# Altium schematic sheet power.SchDoc(power)
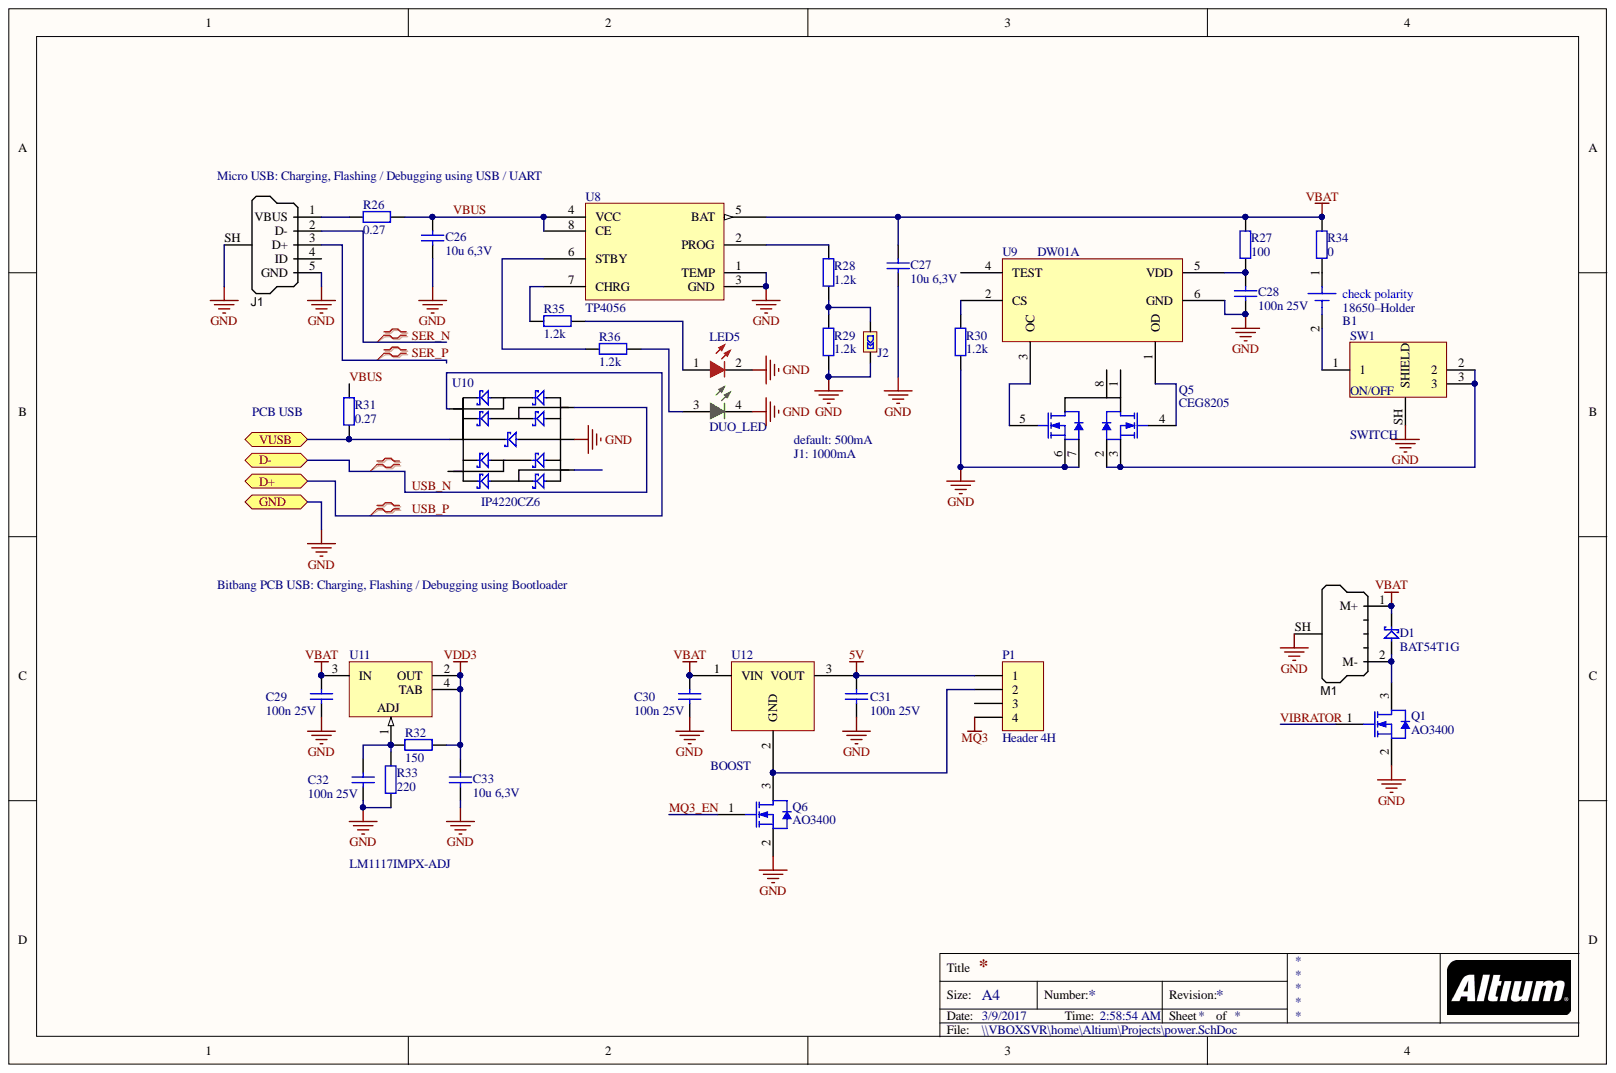
[diagram p0: power.SchDoc]

## Parts
B1  18650–Holder  [18650–Holder]
C26  10u 6,3V  [C-0603-H]
C27  10u 6,3V  [C-0603-H]
C28  100n 25V  [C-0603-M]
C29  100n 25V  [C-0603-M]
C30  100n 25V  [C-0603-M]
C31  100n 25V  [C-0603-M]
C32  100n 25V  [C-0603-M]
C33  10u 6,3V  [C-0603-H]
D1  BAT54T1G  [SOD123]
J1  USB Micro Type B (recommended if hand soldering)  [USB_Micro-Molex]  (value not drawn)
J2  jumper  [JUMPER 1-1]  (value not drawn)
LED5  DUO_LED  [LEDDUO]
M1  VIBRATOR  [VIBRATOR_SMD]
P1  Header 4H  [HDR1X4H]
Q1  AO3400  [AO-SOT23-3_V]
Q5  CEG8205  [TSSOP8]
Q6  AO3400  [AO-SOT23-3_V]
R26  0.27  [R-1206-M]
R27  100  [R-0603-M]
R28  1.2k  [R-0603-M]
R29  1.2k  [R-0603-M]
R30  1.2k  [R-0603-M]
R31  0.27  [R-1206-M]
R32  150  [R-0603-M]
R33  220  [R-0603-M]
R34  0  [R-0603-M]
R35  1.2k  [R-0603-M]
R36  1.2k  [R-0603-M]
SW1  SWITCH  [SWITCH]
U8  TP4056  [SOIC127P600X20_HS-9N]
U9  DW01A  [SOT23-6]
U10  IP4220CZ6  [SOT23-6]
U11  LM1117IMPX-ADJ  [MP04A_L]
U12  BOOST  [BOOST]

## Ports
D+, D-, GND, VUSB

## Nets
5V: C31.2 P1.1 U12.3
MQ3: P1.4
VBAT: C27.2 C29.2 C30.2 D1.2 M1.1 R27.2 R34.2 U8.5(BAT) U11.3(IN) U12.1
VDD3: C33.2 R32.1 U11.2(OUT) U11.4(TAB)
GND: C26.1 C27.1 C28.1 C29.1 C30.1 C31.1 C32.1 C33.1 J1.5(GND) J1.SH J2.2 LED5.2 LED5.4 M1.SH Q1.2 Q5.6 Q5.7 Q6.2 R29.1 R30.1 R33.1 SW1.SH(SHIELD) U8.1(TEMP) U8.3(GND) U9.6(GND) U10.2 ; port GND
MQ3_EN: Q6.1
SER_N: J1.2(D-)
SER_P: J1.3(D+)
USB_N: U10.3
USB_P: U10.4
VBUS: C26.2 R26.2 R31.2 U8.4(VCC) U8.8(CE)
VIBRATOR: Q1.1
VUSB: R31.1 U10.5 ; port VUSB
NetB1_1: B1.1 R34.1
NetB1_2: B1.2 SW1.1
NetC28_2: C28.2 R27.1 U9.5(VDD)
NetC32_2: C32.2 R32.2 R33.2 U11.1
NetD1_1: D1.1 M1.2 Q1.3
NetJ1_1: J1.1(VBUS) R26.1
NetJ2_1: J2.1 R28.1 R29.2
NetLED5_1: LED5.1 R35.1
NetLED5_3: LED5.3 R36.1
NetP1_2: P1.2 Q6.3 U12.2
NetQ5_2: Q5.2 Q5.3 SW1.2 SW1.3
NetQ5_4: Q5.4 U9.1(OD)
NetQ5_5: Q5.5 U9.3(OC)
NetR28_2: R28.2 U8.2(PROG)
NetR30_2: R30.2 U9.2(CS)
NetR35_2: R35.2 U8.7(CHRG)
NetR36_2: R36.2 U8.6(STBY)

Not connected: J1 4(ID); P1 3; Q5 1 8; U9 4(TEST); U10 1 6

## Library data of the parts
C26 C27 C33 = C-0603-10u-6,3V: Capacitor 10uF 6,3V; Supplier Part Number 1: 80-C0603C106M9P; Supplier 1: Mouser; Library Name: Passives.IntLib; Component Kind: Standard
C28-C32 = C-0603-100n-25V: Capacitor 100n 25V; Supplier Part Number 1: 80-C0603C104K3R; Supplier 1: Mouser; Library Name: Passives.IntLib; Component Kind: Standard
D1 = D-BAT54T1G: Supplier Part Number 1: 863-BAT54T1G; Supplier 1: Mouser; Library Name: advanced.IntLib; Component Kind: Standard
J1 = USB_Micro_MOLEX: Connector SMD-THD USB Micro Type B middle mount; Component Kind: Standard; Library Name: GPN–Badge.SchLib
J2 = jumper 1-1: Library Name: advanced.SchLib; Component Kind: Standard
M1 = VIBRATOR: rawr; Component Kind: Standard; Library Name: GPN–Badge.SchLib
P1 = Header 4H: Header, 4-Pin, Right Angle; Signal Integrity: Connector; Library Name: Miscellaneous Connectors.IntLib; LatestRevisionNote: Re-released for DXP Platform.; LatestRevisionDate: 17-Jul-2002; Component Kind: Standard; Publisher: Altium Limited
Q1 Q6 = CMP-0278-00001-1: N-channel Enhancement Mode Field-Effect Transistor; 5.8A/30V; Manufacturer: Alpha and Omega; Junction Temperature: -55 to 150 degC; Gate-Source Voltage Limit: +/-12 V; Drain-Source Voltage Limit: 30 V; Channel Type: N; Storage Temperature: -55 to 150 degC; PartNumber: AO3400; PackageReference: SOT23; PackageDescription: 3-Pin SOT23, 3.016 x 2.8 mm Body, 0.95 mm Pitch; Mounting Technology: Surface Mount; Library Name: Altium Content Vault; DatasheetVersion: Rev.8, 12/2011; ComponentLink3URL: http://www.aosmd.com/res/packaging_information/SOT-23.pdf; ComponentLink3Description: Package Specification; ComponentLink2URL: http://www.aosmd.com/res/data_sheets/AO3400.pdf; ComponentLink2Description: Datasheet; ComponentLink1URL: http://www.aosmd.com/; ComponentLink1Description: Manufacturer URL; Component Kind: Standard
Q5 = MOSFET-CEG8205: Dual mini mosfet 20V 4.5A; Supplier 1: AliExpress; Library Name: advanced.SchLib; Component Kind: Standard
R26 R31 = R-1206-0R27: Resistor 0.27; Supplier Part Number 1: 71-RCWE1206R270FKEA; Supplier 1: Mouser; Library Name: Passives.IntLib; Component Kind: Standard
R27 = R-0603-100: Resistor 100; Supplier Part Number 1: 71-CRCW0603-100-E3; Supplier 1: Mouser; Library Name: Passives.IntLib; Component Kind: Standard
R28-R30 R35 R36 = R-0603-1k2: Resistor 1.2k; Library Name: Passives.IntLib; Component Kind: Standard
R32 = R-0603-150: Resistor 150; Supplier Part Number 1: 71-CRCW0603-150K-E3; Supplier 1: Mouser; Library Name: Passives.IntLib; Component Kind: Standard
R33 = R-0603-220: Resistor 220; Supplier Part Number 1: 541-220HTR-ND; Supplier 1: Digi-Key; Library Name: Passives.IntLib; Component Kind: Standard
R34 = R-0603-0: Resistor 0; Supplier Part Number 1: 71-CRCW0603-0-E3; Supplier 1: Mouser; Resistance: 0; Library Name: Passives.IntLib; Component Kind: Standard
U8 = TP4056: 1A standalone linear charging IC; Supplier 1: AliExpress; Library Name: advanced.IntLib; Component Kind: Standard
U9 = DW01A: Single Cell LiIon LiPo Protection; Library Name: advanced.SchLib; Component Kind: Standard
U10 = IP4220CZ6: USB ESD Protection; Library Name: advanced.SchLib; Component Kind: Standard
U11 = CMP-0062-01617-3: 800mA Low-Dropout Linear Regulator, 4-pin SOT-223; Manufacturer: National Semiconductor; PowerWise: N; PackageTopMarking: XYTT*N03B; High-Reliability: Y; CompType: LDO IC; RoHS: N; RevisionNote: SCH symbol layout adjusted; PinCount: 4; PartNumber: LM1117IMPX-ADJ; PackageVersion: revB, Apr-2003; PackageReference: MP04A; PackageDescription: Molded SOT-223, 6.50 x 3.56 x 1.6mm Body, 4 Lead, 2.3mm Pitch; Library Name: Altium Content Vault; DatasheetVersion: Apr-2006; ComponentLink4URL: http://www.national.com/packaging/folders/mp04a.html; ComponentLink4Description: MP04A Package Page; ComponentLink3URL: http://www.national.com/pf/LM/LM1117.html; ComponentLink3Description: LM1117 Web Page; ComponentLink2URL: http://www.national.com/ds/LM/LM1117.pdf; ComponentLink2Description: LM1117 Datasheet; ComponentLink1URL: http://www.national.com/; ComponentLink1Description: Manufacturer URL; Component Kind: Standard; Code_JEDEC: TO-261-AA; Code_IPC: SOT223-4
B1 = 18650–Holder: Component Kind: Standard; Library Name: GPN–Badge.SchLib
LED5 = DUO_LED: Component Kind: Standard; Library Name: GPN–Badge.SchLib
SW1 = SWITCH: Component Kind: Standard; Library Name: GPN–Badge.SchLib
U12 = BOOST: Component Kind: Standard; Library Name: GPN–Badge.SchLib
